# Altium schematic sheet QZ_STC_R02.SchDoc
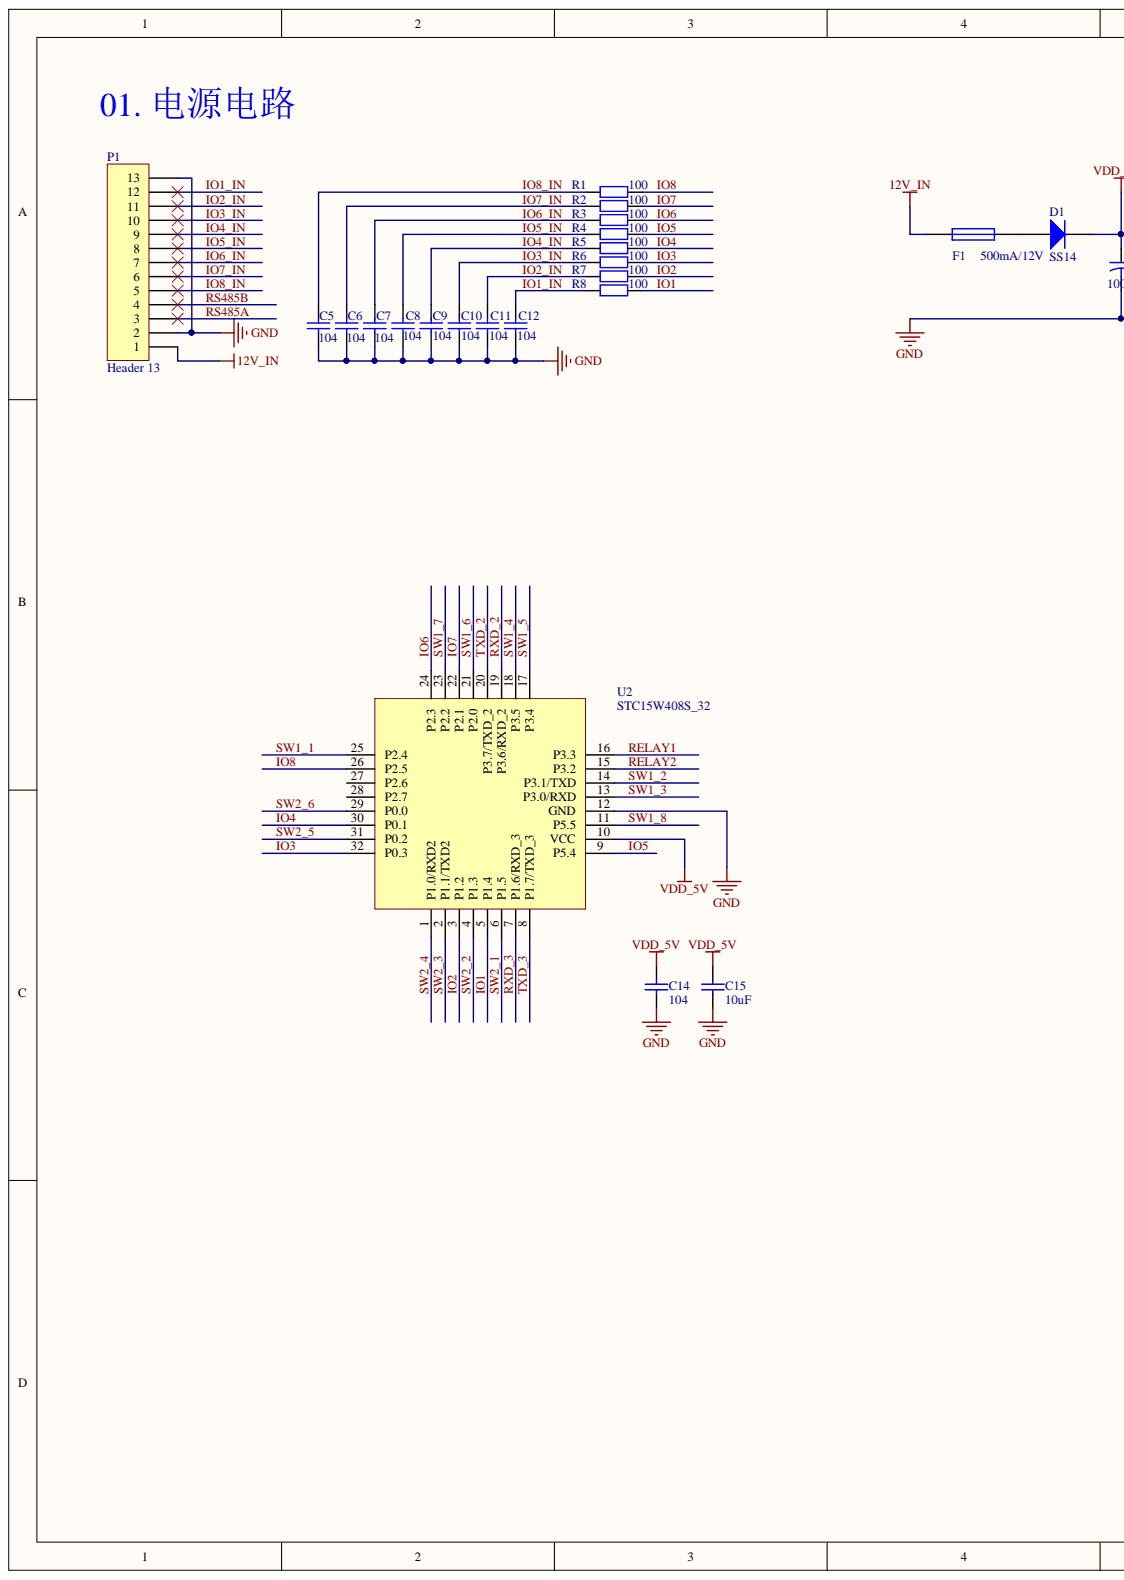
[diagram p0: QZ_STC_R02.SchDoc, left]
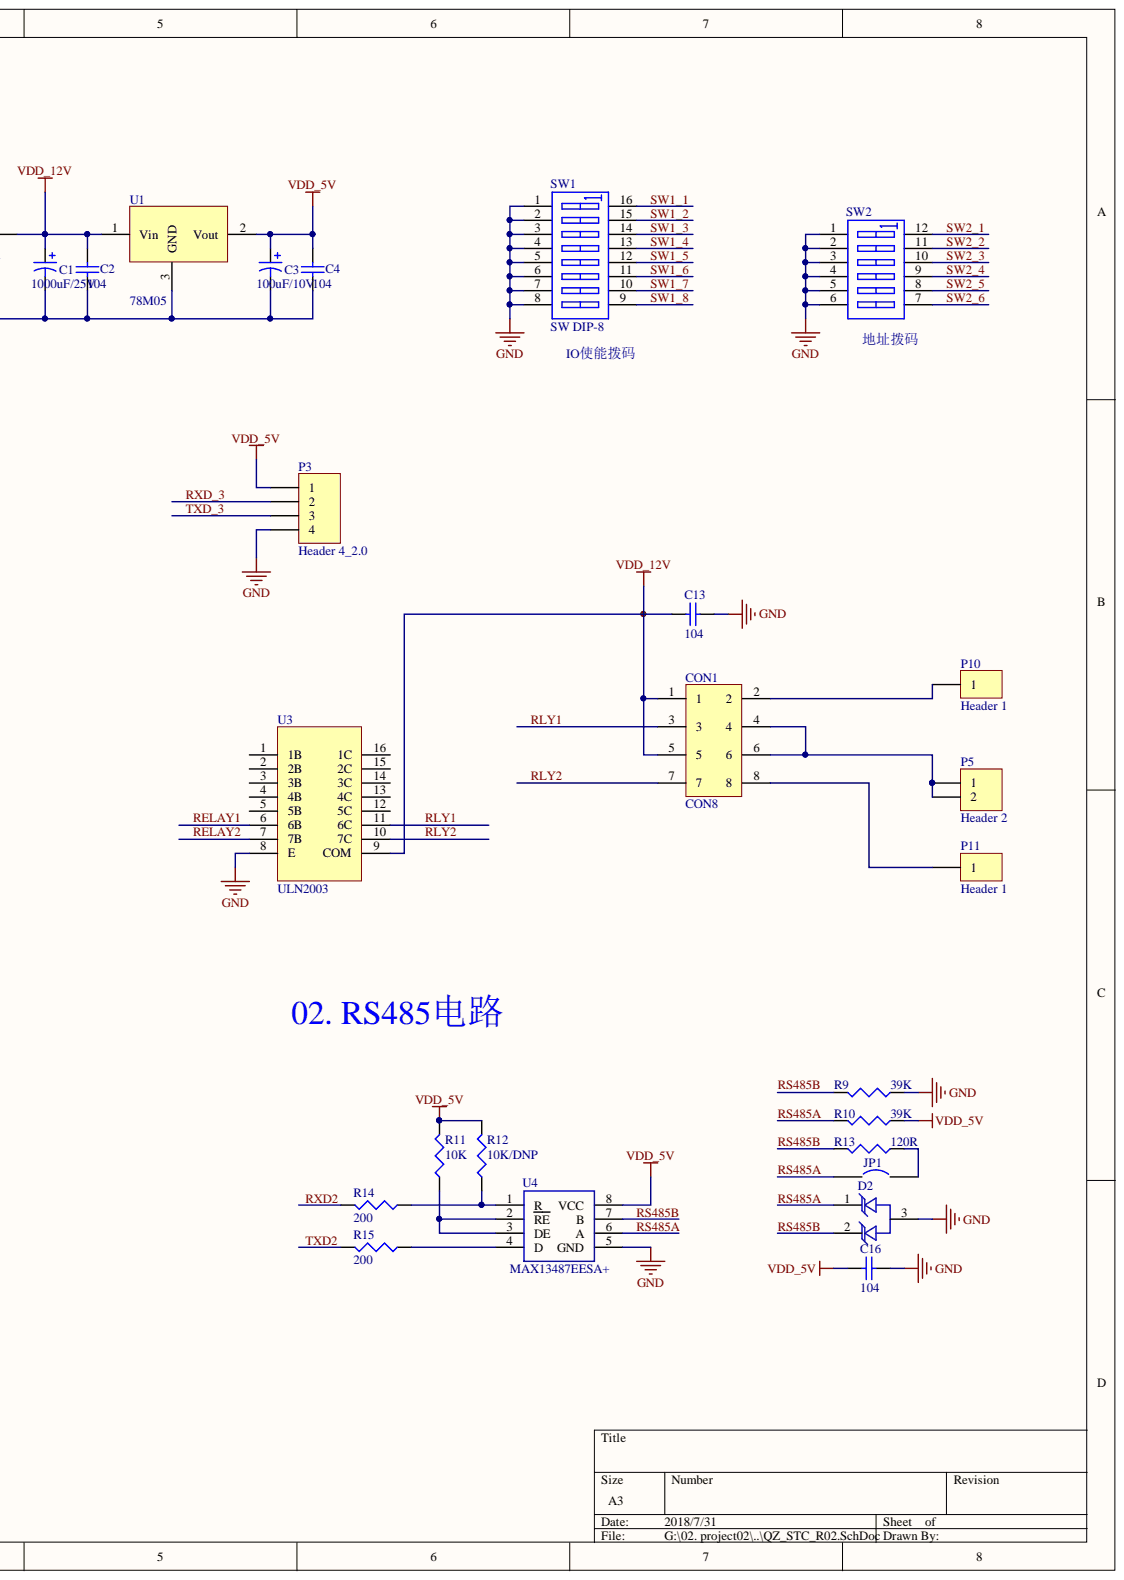
[diagram p1: QZ_STC_R02.SchDoc, right]

## Parts
C1  value 1000uF/25V  [1000uf_sop]  (hidden comment: 1000uF/35V)
C2  104  [0603C+]
C3  100uF/10V  [TC3528B]
C4  104  [0603C+]
C5  104  [0603C+]
C6  104  [0603C+]
C7  104  [0603C+]
C8  104  [0603C+]
C9  104  [0603C+]
C10  104  [0603C+]
C11  104  [0603C+]
C12  104  [0603C+]
C13  104  [0603C+]
C14  104  [0603C+]
C15  10uF  [0603C+]
C16  104  [0603C+]
CON1  CON8  [RELAY_2_W]
D1  SS14  [DO214-AC]
D2  RClamp0502B  [SOT-523]  (value not drawn)
F1  500mA/12V  [R_1210]
JP1  JUMPER  [SMT_JP]  (value not drawn)
P1  Header 13  [PIN13_1.25]
P3  Header 4_2.0  [SWD2_V]
P5  Header 2  [PIN2_11_11.8]
P10  Header 1  [PIN1_11_11.8]
P11  Header 1  [PIN1_11_11.8]
R1  100  [0603R+]
R2  100  [0603R+]
R3  100  [0603R+]
R4  100  [0603R+]
R5  100  [0603R+]
R6  100  [0603R+]
R7  100  [0603R+]
R8  100  [0603R+]
R9  39K  [0603R+]
R10  39K  [0603R+]
R11  10K  [0603R+]
R12  10K/DNP  [0603R+]
R13  120R  [0603R+]
R14  200  [0603R+]
R15  200  [0603R+]
SW1  SW DIP-8  [DIP-16]
SW2  SW DIP-6  [DIP12]  (value not drawn)
U1  78M05  [78M05]
U2  STC15W408S_32  [QFN-32_SMALL]
U3  ULN2003  [SO16]
U4  MAX13487EESA+  [SOIC8N]

## Nets
12V_IN: F1.1 P1.1
GND: C1.2 C2.1 C3.2 C4.1 C5.2 C6.2 C7.2 C8.2 C9.2 C10.2 C11.2 C12.2 C13.1 C14.1 C15.1 C16.1 D2.3 P1.2 P1.13 P3.4 R9.1 SW1.1 SW1.2 SW1.3 SW1.4 SW1.5 SW1.6 SW1.7 SW1.8 SW2.1 SW2.2 SW2.3 SW2.4 SW2.5 SW2.6 U1.3 U2.12(GND) U3.8(E) U4.5(GND)
VDD_5V: C3.1 C4.2 C14.2 C15.2 C16.2 P3.1 R10.1 R11.2 R12.2 U1.2 U2.10(VCC) U4.8(VCC)
VDD_12V: C1.1 C2.2 C13.2 CON1.1 CON1.5 D1.2 U1.1 U3.9(COM)
IO1: R8.1 U2.5(P1.4)
IO1_IN: C12.1 P1.12 R8.2
IO2: R7.1 U2.3(P1.2)
IO2_IN: C11.1 P1.11 R7.2
IO3: R6.1 U2.32(P0.3)
IO3_IN: C10.1 P1.10 R6.2
IO4: R5.1 U2.30(P0.1)
IO4_IN: C9.1 P1.9 R5.2
IO5: R4.1 U2.9(P5.4)
IO5_IN: C8.1 P1.8 R4.2
IO6: R3.1 U2.24(P2.3)
IO6_IN: C7.1 P1.7 R3.2
IO7: R2.1 U2.22(P2.1)
IO7_IN: C6.1 P1.6 R2.2
IO8: R1.1 U2.26(P2.5)
IO8_IN: C5.1 P1.5 R1.2
RELAY1: U2.16(P3.3) U3.6(6B)
RELAY2: U2.15(P3.2) U3.7(7B)
RLY1: CON1.3 U3.11(6C)
RLY2: CON1.7 U3.10(7C)
RS485A: D2.1 JP1.1 P1.3 R10.2 U4.6(A)
RS485B: D2.2 P1.4 R9.2 R13.2 U4.7(B)
RXD2: R14.2
RXD_2: U2.19(P3.6/RXD_2)
RXD_3: P3.2 U2.7(P1.6/RXD_3)
SW1_1: SW1.16 U2.25(P2.4)
SW1_2: SW1.15 U2.14(P3.1/TXD)
SW1_3: SW1.14 U2.13(P3.0/RXD)
SW1_4: SW1.13 U2.18(P3.5)
SW1_5: SW1.12 U2.17(P3.4)
SW1_6: SW1.11 U2.21(P2.0)
SW1_7: SW1.10 U2.23(P2.2)
SW1_8: SW1.9 U2.11(P5.5)
SW2_1: SW2.12 U2.6(P1.5)
SW2_2: SW2.11 U2.4(P1.3)
SW2_3: SW2.10 U2.2(P1.1/TXD2)
SW2_4: SW2.9 U2.1(P1.0/RXD2)
SW2_5: SW2.8 U2.31(P0.2)
SW2_6: SW2.7 U2.29(P0.0)
TXD2: R15.2
TXD_2: U2.20(P3.7/TXD_2)
TXD_3: P3.3 U2.8(P1.7/TXD_3)
NetCON1_2: CON1.2 P10.1
NetCON1_4: CON1.4 CON1.6 P5.1 P5.2
NetCON1_8: CON1.8 P11.1
NetD1_1: D1.1 F1.2
NetJP1_2: JP1.2 R13.1
NetR11_1: R11.1 U4.2(RE) U4.3(DE)
NetR12_1: R12.1 R14.1 U4.1(R)
NetR15_1: R15.1 U4.4(D)

Not connected: U2 27(P2.6) 28(P2.7); U3 1(1B) 2(2B) 3(3B) 4(4B) 5(5B) 12(5C) 13(4C) 14(3C) 15(2C) 16(1C)

## Library data of the parts
C1 C3 = Cap Pol1: Polarized Capacitor (Radial); Component Kind: Standard; LatestRevisionDate: 17-Jul-2002; LatestRevisionNote: Re-released for DXP Platform.; Library Name: Miscellaneous Devices.IntLib; PackageDescription: Polarized Capacitor (Radial); 2 Leads; PackageReference: RB7.6-15; Published: 8-Jun-2000; Simulation: CAP; Publisher: Altium Limited
  C3: Value: 100uF/10V
C2 C4-C16 = Cap: Capacitor; Component Kind: Standard; LatestRevisionDate: 17-Jul-2002; LatestRevisionNote: Re-released for DXP Platform.; Library Name: Miscellaneous Devices.IntLib; PackageDescription: Radial Cap, Thru-Hole; 2 Leads; 0.3 in Pin Spacing; PackageReference: RAD-0.3; Published: 8-Jun-2000; Publisher: Altium Limited
  C2 C4: Simulation: CAP; Value: 104
  C5-C14 C16: Value: 104
  C15: Value: 10uF
D1 = Diode 1N914: High Conductance Fast Diode; Code_JEDEC: DO-204-AH; Component Kind: Standard; ComponentLink1Description: Manufacturer Link; ComponentLink1URL: http://www.fairchildsemi.com/; ComponentLink2Description: Datasheet; ComponentLink2URL: http://www.fairchildsemi.com/ds/1N%2F1N4448.pdf; DatasheetVersion: 1997; LatestRevisionDate: 17-Jul-2002; LatestRevisionNote: Re-released for DXP Platform.; Library Name: Miscellaneous Devices.IntLib; PackageDescription: Diode, Axial; Body 3.8 x 1.9 mm (LxDia. max), Lead Dia. 0.53 mm (max); PackageReference: DO-35; Published: 8-Jun-2000; Simulation: 1N914; Publisher: Altium Limited
D2 = LCTVS: Component Kind: Standard; Library Name: Sch.SchLib; Value: RClamp0502B
F1 = Fuse 1: Fuse; Component Kind: Standard; LatestRevisionDate: 17-Jul-2002; LatestRevisionNote: Re-released for DXP Platform.; Library Name: Miscellaneous Devices.IntLib; PackageDescription: Thru-Hole; 2 Leads; PackageReference: PIN-W2/E2.8; Published: 8-Jun-2000; Simulation: FUSE; Publisher: Altium Limited
JP1 = Circuit Breaker: Component Kind: Standard; Description: Circuit Breaker; LatestRevisionDate: 17-Jul-2002; LatestRevisionNote: Re-released for DXP Platform.; Library Name: Miscellaneous Devices.IntLib; PackageDescription: Switch; 2 Leads; PackageReference: SPST-2; Published: 24-Mar-1999; Value: JUMPER; Publisher: Altium Limited
P1 = Header 13: Header, 13-Pin; Component Kind: Standard; LatestRevisionDate: 17-Jul-2002; LatestRevisionNote: Re-released for DXP Platform.; Library Name: Miscellaneous Connectors.IntLib; Publisher: Altium Limited
P3 = Header 4: Header, 4-Pin; Component Kind: Standard; LatestRevisionDate: 17-Jul-2002; LatestRevisionNote: Re-released for DXP Platform.; Library Name: Miscellaneous Connectors.IntLib; Publisher: Altium Limited
P5 = Header 2: Header, 2-Pin; Component Kind: Standard; LatestRevisionDate: 17-Jul-2002; LatestRevisionNote: Re-released for DXP Platform.; Library Name: Miscellaneous Connectors.IntLib; Publisher: Altium Limited
R1-R8 = Res2: Resistor; Component Kind: Standard; LatestRevisionDate: 17-Jul-2002; LatestRevisionNote: Re-released for DXP Platform.; Library Name: Miscellaneous Devices.IntLib; PackageDescription: Axial Device, Thru-Hole; 2 Leads; 0.4 in Pin Spacing; PackageReference: AXIAL-0.4; Published: 8-Jun-2000; Simulation: RESISTOR; Value: 100; Publisher: Altium Limited
R9-R15 = Res3: Resistor; Component Kind: Standard; LatestRevisionDate: 29-May-2009; LatestRevisionNote: IPC-7351 Footprint Added.; Library Name: Miscellaneous Devices.IntLib; PackageDescription: Chip Resistor; PackageReference: J1-0603; Published: 8-Jun-2000; Simulation: RESISTOR; Publisher: Altium Limited
  R9 R10: Value: 39K
  R11: Value: 10K
  R12: Value: 10K/DNP
  R13: Value: 120R
  R14 R15: Value: 200
SW1 = SW DIP-8: DIP Switch, 8 Position, SPST; Component Kind: Standard; LatestRevisionDate: 17-Jul-2002; LatestRevisionNote: Re-released for DXP Platform.; Library Name: Miscellaneous Devices.IntLib; PackageDescription: DIP Switch; 16 Leads; PackageReference: DIP_SW_8WAY_SMD; Published: 24-Mar-1999; Simulation: dpsw8; Publisher: Altium Limited
SW2 = SW DIP-6: DIP Switch, 6 Position, SPST; Component Kind: Standard; LatestRevisionDate: 17-Jul-2002; LatestRevisionNote: Re-released for DXP Platform.; Library Name: Miscellaneous Devices.IntLib; PackageDescription: DIP Switch; 12 Leads; PackageReference: DIP-12/SW; Published: 24-Mar-1999; Simulation: dpsw6; Publisher: Altium Limited
U4 = SN65HVD3082E: Component Kind: Standard; Library Name: Sch.SchLib
CON1 = CON8: Component Kind: Standard; Description: CON8; Library Name: Schlib1.SchLib
P10 P11 = Header 1: Component Kind: Standard; Library Name: QZ_S03.SCHLIB
U1 = 78M05: Component Kind: Standard; Library Name: PRJ_QZ.SCHLIB
U2 = STC15W408S_32: Component Kind: Standard; Library Name: PCB_Project.SCHLIB
U3 = ULN2003: Component Kind: Standard; Library Name: Schlib1.SchLib
